# Altium schematic sheet salor model2.0.SchDoc("salor model2.0")
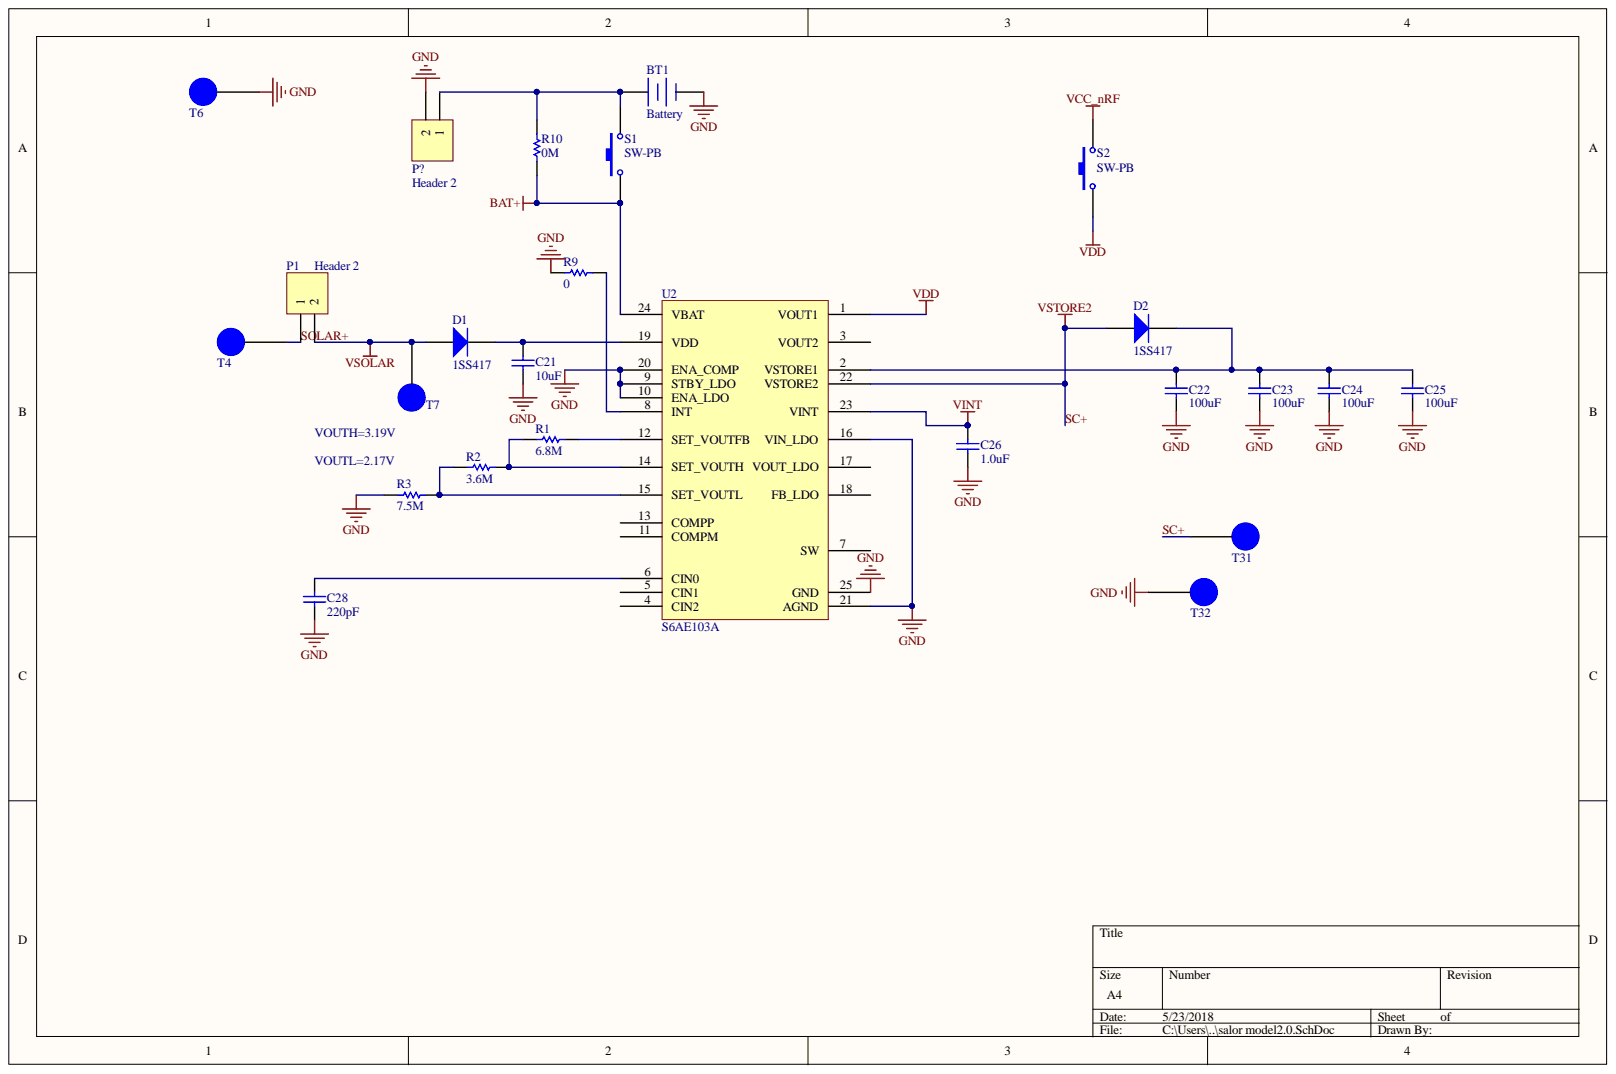
[diagram p0: salor model2.0.SchDoc]

## Parts
BT1  Battery  [P-1.25T-2P]
C21  10uF  [0603_CAPC1608X06L_0603]
C22  100uF  [C1206]
C23  100uF  [C1206]
C24  100uF  [C1206]
C25  100uF  [C1206]
C26  1.0uF  [0603_CAPC1608X06L_0603]
C28  220pF  [0402_CAPC1005X04L]
D1  Type: 1SS417  [SOD523]  (hidden comment: Diode)
D2  Type: 1SS417  [SOD523]  (hidden comment: Diode)
P1  Header 2  [HDR1X2]
P?  Header 2  [HDR1X2]
R1  6.8M  [0603_INDC1608X06L]
R2  3.6M  [0603_INDC1608X06L]
R3  7.5M  [0603_INDC1608X06L]
R9  0  [0603_INDC1608X06L]
R10  0M  [0603_INDC1608X06L]
S1  SW-PB  [SW-SSSS811101]
S2  SW-PB  [SW-SSSS811101]
T4  TP  [TP1]  (value not drawn)
T6  TP  [TP1]  (value not drawn)
T7  TP  [TP1]  (value not drawn)
T31  TP  [TP2]  (value not drawn)
T32  TP  [TP2]  (value not drawn)
U2  S6AE103A  [QFN24-S6AE103]

## Nets
BAT+: R10.1 S1.1 U2.24(VBAT)
GND: BT1.2 C21.1 C22.1 C23.1 C24.1 C25.1 C26.1 C28.1 P?.2 R3.1 R9.1 T6.1 T32.1 U2.9(STBY_LDO) U2.10(ENA_LDO) U2.16(VIN_LDO) U2.20(ENA_COMP) U2.21(AGND) U2.25(GND)
VCC_nRF: S2.2
VDD: S2.1 U2.1(VOUT1)
VINT: C26.2 U2.23(VINT)
VSOLAR: D1.1 P1.2 T7.1
SC+: D2.1 T31.1 U2.22(VSTORE2)
SOLAR+: P1.1 T4.1
NetBT1_1: BT1.1 P?.1 R10.2 S1.2
NetC21_2: C21.2 D1.2 U2.19(VDD)
NetC22_2: C22.2 C23.2 C24.2 C25.2 D2.2 U2.2(VSTORE1)
NetC28_2: C28.2 U2.6(CIN0)
NetR1_1: R1.1 R2.2 U2.14(SET_VOUTH)
NetR1_2: R1.2 U2.12(SET_VOUTFB)
NetR2_1: R2.1 R3.2 U2.15(SET_VOUTL)
NetR9_2: R9.2 U2.8(INT)

Not connected: U2 3(VOUT2) 4(CIN2) 5(CIN1) 7(SW) 11(COMPM) 13(COMPP) 17(VOUT_LDO) 18(FB_LDO)

## Library data of the parts
BT1 = Battery: Multicell Battery; Published: 24-Mar-1999; PackageReference: BAT-2; PackageDescription: Battery; 2 Leads; Library Name: Miscellaneous Devices.IntLib; LatestRevisionNote: Re-released for DXP Platform.; LatestRevisionDate: 17-Jul-2002; Component Kind: Standard; Publisher: Altium Limited
C21-C26 C28 = Cap: 无极性电容; Published: 8-Jun-2000; PackageReference: RAD-0.3; Library Name: sp.SchLib; LatestRevisionNote: Re-released for DXP Platform.; LatestRevisionDate: 17-Jul-2002; Component Kind: Standard; Publisher: Altium Limited
  C21: Value: 10uF
  C22-C25: Value: 100uF
  C26: Value: 1.0uF
  C28: Value: 220pF
D1 D2 = Diode: 1N4001; Published: 8-Jun-2000; PackageReference: SMC; PackageDocument: Sep-1996; Library Name: sp.SchLib; LatestRevisionNote: Re-released for DXP Platform.; LatestRevisionDate: 17-Jul-2002; Component Kind: Standard; Code_JEDEC: DO-214-AB; Publisher: Altium Limited
P1 P? = Header 2: Header, 2-Pin; Signal Integrity: Connector; Library Name: Miscellaneous Connectors.IntLib; LatestRevisionNote: Re-released for DXP Platform.; LatestRevisionDate: 17-Jul-2002; Component Kind: Standard; Publisher: Altium Limited
R1-R3 R9 R10 = Res1: Resistor; Simulation: RESISTOR; Signal Integrity: Res; Published: 8-Jun-2000; PackageReference: AXIAL-0.3; PackageDescription: Axial Device, Thru-Hole; 2 Leads; 0.3 in Pin Spacing; Library Name: sp.SchLib; LatestRevisionNote: Re-released for DXP Platform.; LatestRevisionDate: 17-Jul-2002; Component Kind: Standard; Publisher: Altium Limited
  R1: Value: 6.8M
  R2: Value: 3.6M
  R3: Value: 7.5M
  R9: Value: 0
  R10: Value: 0M
S1 S2 = SW-PB: Switch; Published: 24-Mar-1999; PackageReference: SPST-2; PackageDescription: Switch; 2 Leads; Library Name: Miscellaneous Devices.IntLib; LatestRevisionNote: Re-released for DXP Platform.; LatestRevisionDate: 17-Jul-2002; Component Kind: Standard; Publisher: Altium Limited
U2 = S6AE103A_1: PMIC; Library Name: sp.SchLib; Component Kind: Standard
T4 T6 T7 T31 T32 = TP: Library Name: sp.SchLib; Component Kind: Standard
